# Altium schematic sheet Charging.SchDoc
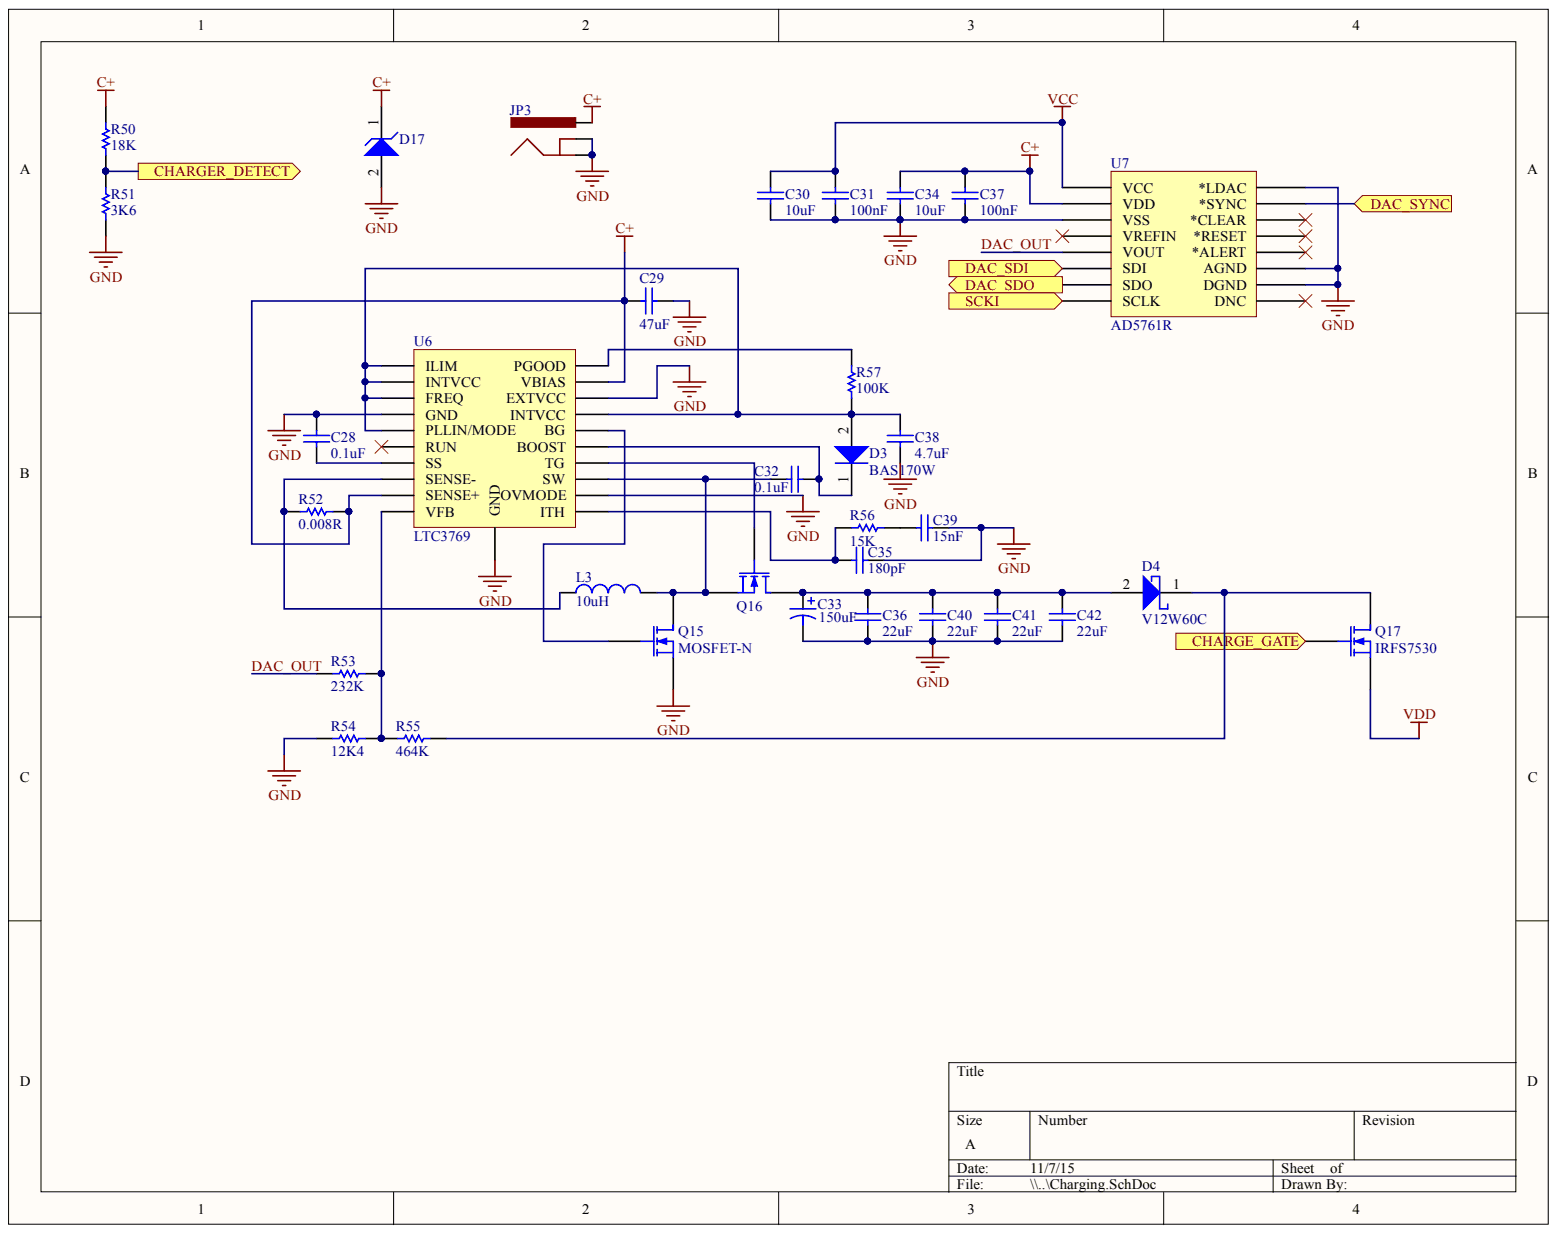
[diagram p0: Charging.SchDoc]

## Parts
C28  0.1uF  [CAPC0603(1608)100_M]
C29  47uF  [CAPC1206(3216)190_M]
C30  10uF  [CAPC0805(2012)145_N]
C31  100nF  [CAPC0603(1608)100_N]
C32  0.1uF  [CAPC0603(1608)100_M]
C33  value 150uF  [CAP 180uF 50V]
C34  10uF  [CAPC0805(2012)145_N]
C35  180pF  [CAPC0603(1608)100_M]
C36  22uF  [CAPC2220(5750)230_N]
C37  100nF  [CAPC0603(1608)100_N]
C38  4.7uF  [CAPC0603(1608)55_M]
C39  15nF  [CAPC0603(1608)100_L]
C40  22uF  [CAPC2220(5750)230_N]
C41  22uF  [CAPC2220(5750)230_N]
C42  22uF  [CAPC2220(5750)230_N]
D3  BAS170W  [INF-SOD323_V]
D4  V12W60C  [DPAK229P1003X238-3N]
D17  (no value)  [ONSC-SOD-123-2-425-04_V]
JP3  (no value)  [POWER_JACK_SMD_OVERPASTE_REDBOARD_0603]
L3  value 10uH  [IHLP-6767GZ]
Q15  MOSFET-N  [SOIC127P600X175-8N]
Q16  (no value)  [SOIC127P600X175-8N]
Q17  IRFS7530  [DPAK127P1525X483-7N]
R50  18K  [RESC0603(1608)_M]
R51  3K6  [RESC0603(1608)_L]
R52  0.008R  [RESC2010(5025)_L]
R53  232K  [RESC0603(1608)_N]
R54  12K4  [RESC0603(1608)_M]
R55  464K  [RESC0603(1608)_M]
R56  15K  [RESC0603(1608)_M]
R57  100K  [RESC0603(1608)_N]
U6  LTC3769  [TSOP65P640X120_HS-21N]
U7  AD5761R  [RU_16]

## Ports
CHARGE_GATE, CHARGER_DETECT, DAC_SDI, DAC_SDO, DAC_SYNC, SCKI

## Nets
C+: C29.1 C34.2 C37.2 D17.1 JP3.VIN0 R50.2 R52.2 U6.9(SENSE+) U6.19(VBIAS) U7.8(VDD)
GND: C28.2 C29.2 C30.1 C31.1 C33.2 C34.1 C35.2 C36.1 C37.1 C38.1 C39.2 C40.1 C41.1 C42.1 D17.2 JP3.GND JP3.P$4 Q15.3 R51.1 R54.1 U6.4(GND) U6.12(OVMODE) U6.18(EXTVCC) U6.21(GND) U7.5(AGND) U7.6(VSS) U7.11(*LDAC) U7.16(DGND)
VCC: C30.2 C31.2 U7.15(VCC)
VDD: Q17.3
CHARGE_GATE: Q17.2 ; port CHARGE_GATE
CHARGER_DETECT: R50.1 R51.2 ; port CHARGER_DETECT
DAC_OUT: R53.1 U7.7(VOUT)
DAC_SDI: U7.12(SDI) ; port DAC_SDI
DAC_SDO: U7.10(SDO) ; port DAC_SDO
DAC_SYNC: U7.13(*SYNC) ; port DAC_SYNC
SCKI: U7.14(SCLK) ; port SCKI
NetC28_1: C28.1 U6.7(SS)
NetC32_1: C32.1 L3.2 Q15.2 Q16.3 U6.13(SW)
NetC32_2: C32.2 D3.1 U6.15(BOOST)
NetC33_1: C33.1 C36.2 C40.2 C41.2 C42.2 D4.2 Q16.2
NetC35_1: C35.1 R56.1 U6.11(ITH)
NetC38_2: C38.2 D3.2 R57.1 U6.1(ILIM) U6.2(INTVCC) U6.3(FREQ) U6.5(PLLIN/MODE) U6.17(INTVCC)
NetC39_1: C39.1 R56.2
NetD4_1: D4.1 Q17.1 R55.2
NetL3_1: L3.1 R52.1 U6.8(SENSE-)
NetQ15_1: Q15.1 U6.16(BG)
NetQ16_1: Q16.1 U6.14(TG)
NetR53_2: R53.2 R54.2 R55.1 U6.10(VFB)
NetR57_2: R57.2 U6.20(PGOOD)

Not connected: U6 6(RUN); U7 1(*ALERT) 2(*CLEAR) 3(*RESET) 4(VREFIN) 9(DNC)

## Library data of the parts
C28 C32 = CMP-1035-02575-1: CAP 100nF 6.3V Â±5% 0603 (1608 Metric) Thickness 1mm SMD; Case-EIA: 0603; Case-Metric: 1608; Component Kind: Standard; Library Name: Altium Content Vault; Max Thickness: 1 mm; Rated Voltage: 6.3 V; Technology: SMT; Tolerance: Â±5%; Value: 100nF
C29 = CMP-1037-04995-1: CAP 22uF 25V Â±20% 1206 (3216 Metric) Thickness 1.9mm SMD; Case-EIA: 1206; Case-Metric: 3216; Component Kind: Standard; Library Name: Altium Content Vault; Max Thickness: 1.9 mm; Rated Voltage: 25 V; Technology: SMT; Tolerance: Â±20%; Value: 22uF
C30 C34 = CMP-1036-04932-1: CAP 10uF 25V Â±10% 0805 (2012 Metric) Thickness 1.45mm SMD; Case-EIA: 0805; Case-Metric: 2012; Component Kind: Standard; Library Name: Altium Content Vault; Max Thickness: 1.45 mm; Rated Voltage: 25 V; Technology: SMT; Tolerance: Â±10%; Value: 10uF
C31 C37 = CMP-1035-02587-1: CAP 100nF 25V Â±5% 0603 (1608 Metric) Thickness 1mm SMD; Case-EIA: 0603; Case-Metric: 1608; Component Kind: Standard; Library Name: Altium Content Vault; Max Thickness: 1 mm; Rated Voltage: 25 V; Technology: SMT; Tolerance: Â±5%; Value: 100nF
C33 = CAP 180uF 50V: Polarized Capacitor (Axial); Component Kind: Standard; LatestRevisionDate: 17-Jul-2002; LatestRevisionNote: Re-released for DXP Platform.; Library Name: Components.SchLib; PackageDescription: Polarized Capacitor; 2 Leads; PackageReference: POLAR0.8; Published: 8-Jun-2000; Signal Integrity: Cap Pol; Simulation: CAP; Publisher: Altium Limited
C35 = CMP-1035-01398-1: CAP 180pF 6.3V Â±5% 0603 (1608 Metric) Thickness 1mm SMD; Case-EIA: 0603; Case-Metric: 1608; Component Kind: Standard; Library Name: Altium Content Vault; Max Thickness: 1 mm; Rated Voltage: 6.3 V; Technology: SMT; Tolerance: Â±5%; Value: 180pF
C36 C40-C42 = CMP-1042-00782-1: CAP 22uF 50V -20% to +80% 2220 (5750 Metric) Thickness 2.2mm SMD; Case-EIA: 2220; Case-Metric: 5750; Component Kind: Standard; Library Name: Altium Content Vault; Max Thickness: 2.2 mm; Rated Voltage: 50 V; Technology: SMT; Tolerance: -20% to +80%; Value: 22uF
C38 = CMP-1035-02779-1: CAP 4.7uF 6.3V Â±10% 0603 (1608 Metric) Thickness 0.55mm SMD; Case-EIA: 0603; Case-Metric: 1608; Component Kind: Standard; Library Name: Altium Content Vault; Max Thickness: 0.55 mm; Rated Voltage: 6.3 V; Technology: SMT; Tolerance: Â±10%; Value: 4.7uF
C39 = CMP-1035-02358-1: CAP 15nF 6.3V Â±5% 0603 (1608 Metric) Thickness 1mm SMD; Case-EIA: 0603; Case-Metric: 1608; Component Kind: Standard; Library Name: Altium Content Vault; Max Thickness: 1 mm; Rated Voltage: 6.3 V; Technology: SMT; Tolerance: Â±5%; Value: 15nF
D3 = CMP-1182-00005-1: Silicon Schottky Diode, -55 to 125 degC, SOD323, Reel, Green; Manufacturer: Infineon; Configuration: Single; CT (max): 2Â pF; Green: TRUE; IF (max): 70Â mA; VF (max): 1,000Â mV; VR (max): 70Â V; Component Kind: Standard; ComponentLink1Description: Manufacturer URL; ComponentLink1URL: http://www.infineon.com/; ComponentLink2Description: Datasheet; ComponentLink2URL: http://www.infineon.com/dgdl/bas70_bas170series.pdf?folderId=db3a30431400ef68011425f1ca2505e3&fileId=db3a304314dca389011518ad41680e12; ComponentLink3Description: Package Specification; ComponentLink3URL: http://www.infineon.com/dgdl/SOD323-Package_Overview.pdf?folderId=db3a30431936bc4b011952aab84f3bbe&fileId=db3a30431936bc4b011952beed653be3; Library Name: Altium Content Vault; Mounting Technology: Surface Mount; PackageDescription: 2-Pin Small Outline Diode Package, 1.25 x 1.7 mm Body; PackageReference: SOD323; Packing: Reel; PartNumber: BAS170W
D17 = CMP-1060-00237-1: Zener Voltage Regulator, 500 mW, 2-Pin SOD-123, Pb-Free, Tape and Reel; Manufacturer: On Semiconductor; PartNumber: MMSZ24T1G; P Max (W): 0.5; Component Kind: Standard; ComponentLink1Description: Manufacturer URL; ComponentLink1URL: http://www.onsemi.com/; ComponentLink2Description: Datasheet; ComponentLink2URL: http://www.onsemi.com/pub_link/Collateral/MMSZ2V4T1-D.PDF; ComponentLink3Description: Package Specification; ComponentLink3URL: http://www.onsemi.com/pub_link/Collateral/425-04.PDF; DatasheetVersion: Rev. 11; Library Name: Altium Inc., Content Vault; Mounting Technology: Surface Mount; PackageDescription: 2-Pin SOD, Body 1.6 x 2.69 mm; PackageReference: SOD-123-2-425-04; PackageVersion: Rev. G, 10/2009; Packing: Tape and Reel; RoHS: Pb-Free
JP3 = POWER_JACK1: Component Kind: Standard; Library Name: SparkFun-Connectors.IntLib
L3 = IHLP6767: Inductor; Code_IEC: 1005; Code_JEITA: 0402; Component Kind: Standard; LatestRevisionDate: 29-May-2009; LatestRevisionNote: IPC-7351 Footprint Added.; Library Name: Components.SchLib; PackageDescription: Chip Inductor; PackageReference: 0402-A; Published: 8-Jun-2000; Signal Integrity: Inductor; Simulation: INDUCTOR; Publisher: Altium Limited
Q15 Q16 = MOSFET-N: N-Channel MOSFET; Component Kind: Standard; LatestRevisionDate: 17-Jul-2002; LatestRevisionNote: Re-released for DXP Platform.; Library Name: Components.SchLib; PackageDescription: TO, Flat Index; 3 In-Line, Axial Leads; Body Dia. 4.6mm; Lead Dia. 0.5 mm (max); PackageReference: E3; PackageVersion: 1995/1996; Published: 8-Jun-2000; Publisher: Altium Limited
Q17 = IRFS7530: N-Channel MOSFET; Component Kind: Standard; LatestRevisionDate: 17-Jul-2002; LatestRevisionNote: Re-released for DXP Platform.; Library Name: Components.IntLib; PackageDescription: TO, Flat Index; 3 In-Line, Axial Leads; Body Dia. 4.6mm; Lead Dia. 0.5 mm (max); PackageReference: E3; PackageVersion: 1995/1996; Published: 8-Jun-2000; Publisher: Altium Limited
R50 = CMP-1012-00650-1: 18K 0.1W 1% 0603 (1608 Metric)  SMD; Case-EIA: 0603; Case-Metric: 1608; Value: 18000.00 Ohm; Component Kind: Standard; Library Name: Altium Content Vault; Power: 0.1 W; Technology: SMT; Tolerance: 1 %
R51 = CMP-1012-00572-1: 3K6 0.1W 1% 0603 (1608 Metric)  SMD; Case-EIA: 0603; Case-Metric: 1608; Value: 3600.00 Ohm; Component Kind: Standard; Library Name: Altium Content Vault; Power: 0.1 W; Technology: SMT; Tolerance: 1 %
R52 = CMP-1017-00002-1: 1R 0.75W 5% 2010 (5025 Metric)  SMD; Case-EIA: 2010; Case-Metric: 5025; Value: 1.00 Ohm; Component Kind: Standard; Library Name: Altium Content Vault; Power: 0.75 W; Technology: SMT; Tolerance: 5 %
R53 = CMP-1012-00775-1: 232K 0.1W 1% 0603 (1608 Metric)  SMD; Case-EIA: 0603; Case-Metric: 1608; Value: 232000.00 Ohm; Component Kind: Standard; Library Name: Altium Content Vault; Power: 0.1 W; Technology: SMT; Tolerance: 1 %
R54 = CMP-1012-00633-1: 12K4 0.1W 1% 0603 (1608 Metric)  SMD; Case-EIA: 0603; Case-Metric: 1608; Value: 12400.00 Ohm; Component Kind: Standard; Library Name: Altium Content Vault; Power: 0.1 W; Technology: SMT; Tolerance: 1 %
R55 = CMP-1012-00811-1: 464K 0.1W 1% 0603 (1608 Metric)  SMD; Case-EIA: 0603; Case-Metric: 1608; Value: 464000.00 Ohm; Component Kind: Standard; Library Name: Altium Content Vault; Power: 0.1 W; Technology: SMT; Tolerance: 1 %
R56 = CMP-1012-00102-1: 15K 0.1W 5% 0603 (1608 Metric)  SMD; Case-EIA: 0603; Case-Metric: 1608; Value: 15000.00 Ohm; Component Kind: Standard; Library Name: Altium Content Vault; Power: 0.1 W; Technology: SMT; Tolerance: 5 %
R57 = CMP-1012-00122-1: 100K 0.1W 5% 0603 (1608 Metric)  SMD; Case-EIA: 0603; Case-Metric: 1608; Value: 100000.00 Ohm; Component Kind: Standard; Library Name: Altium Content Vault; Power: 0.1 W; Technology: SMT; Tolerance: 5 %
U7 = AD5761BRUZ: Imported; Component Kind: Standard; Library Name: Components.SchLib; RefDes: RefDes; Type: DEV; Value: Value
D4 = V12W60C: Component Kind: Standard; Library Name: Components.SchLib
U6 = LTC3769: Component Kind: Standard; Library Name: Components.SchLib
